# Altium schematic sheet MiniDAP.SchDoc
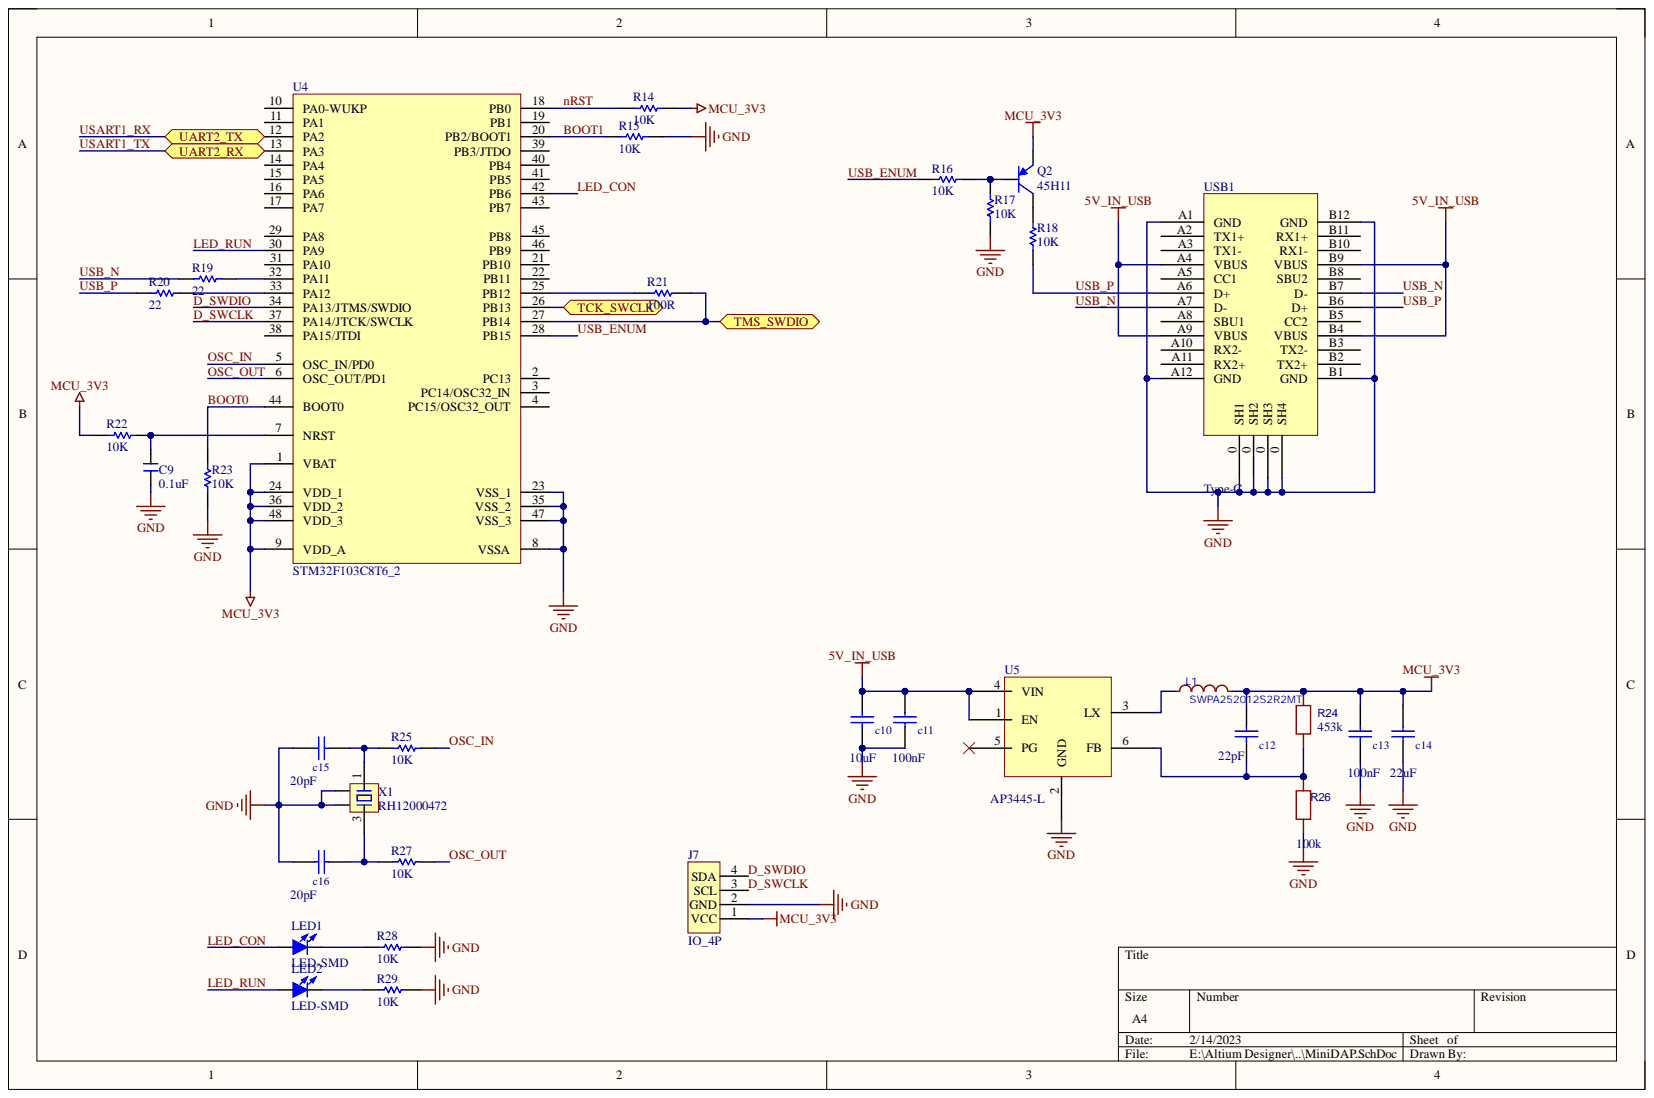
[diagram p0: MiniDAP.SchDoc]

## Parts
C9  0.1uF  [0603_C_Axon]
c10  10uF  [0603_C_Axon]
c11  100nF  [0603_C_Axon]
c12  22pF  [0402_C_Axon]
c13  100nF  [0603_C_Axon]
c14  22uF  [1206_C_Axon]
c15  20pF  [0603_C_Axon]
c16  20pF  [0603_C_Axon]
J7  IO_4P  [HDR1.27-LI-4P]
L1  SWPA252012S2R2MT  [SWPA252012S]
LED1  LED-SMD  [LED_0402_RED]
LED2  LED-SMD  [LED_0402_RED]
Q2  45H11  [SOT_23_3_L_A]
R14  10K  [0603_R_Axon]
R15  10K  [0603_R_Axon]
R16  10K  [0603_R_Axon]
R17  10K  [0603_R_Axon]
R18  10K  [0603_R_Axon]
R19  22  [0603_R_Axon]
R20  22  [0603_R_Axon]
R21  100R  [0603_R_Axon]
R22  10K  [0603_R_Axon]
R23  10K  [0603_R_Axon]
R24  value 453k  [0603_R_Axon]  (hidden comment: RC0603FR-07453KL)
R25  10K  [0603_R_Axon]
R26  value 100k  [0603_R_Axon]  (hidden comment: RC0603FR-07100KL)
R27  10K  [0603_R_Axon]
R28  10K  [0603_R_Axon]
R29  10K  [0603_R_Axon]
U4  STM32F103C8T6_2  [LQFP48_L]
U5  AP3445-L  [SOP6P95_300X285X130L45X42M]
USB1  Type-C  [USB_TYPE_C_FEMALE_12_16P]
X1  RH12000472  [XTAL-SMD-NX3225SA]

## Ports
TCK_SWCLK, TMS_SWDIO, UART2_RX, UART2_TX

## Nets
5V_IN_USB: c10.2 c11.2 U5.1(EN) U5.4(VIN) USB1.A4(VBUS) USB1.A9(VBUS) USB1.B4(VBUS) USB1.B9(VBUS)
GND: C9.1 c10.1 c11.1 c13.1 c14.1 c15.1 c16.1 J7.2(GND) R15.2 R17.1 R23.2 R26.1 R28.2 R29.2 U4.8(VSSA) U4.23(VSS_1) U4.35(VSS_2) U4.47(VSS_3) U5.2(GND) USB1.0(SH1) USB1.A1(GND) USB1.A12(GND) USB1.B1(GND) USB1.B12(GND) X1.2 X1.4
MCU_3V3: c12.2 c13.2 c14.2 J7.1(VCC) L1.2 Q2.3 R14.2 R22.1 R24.2 U4.1(VBAT) U4.9(VDD_A) U4.24(VDD_1) U4.36(VDD_2) U4.48(VDD_3)
BOOT0: R23.1 U4.44(BOOT0)
BOOT1: R15.1 U4.20(PB2/BOOT1)
D_SWCLK: J7.3(SCL) U4.37(PA14/JTCK/SWCLK)
D_SWDIO: J7.4(SDA) U4.34(PA13/JTMS/SWDIO)
LED_CON: LED1.1 U4.42(PB6)
LED_RUN: LED2.1 U4.30(PA9)
nRST: R14.1 U4.18(PB0)
OSC_IN: R25.2 U4.5(OSC_IN/PD0)
OSC_OUT: R27.2 U4.6(OSC_OUT/PD1)
TCK_SWCLK: U4.26(PB13) ; port TCK_SWCLK
TMS_SWDIO: R21.2 U4.27(PB14) ; port TMS_SWDIO
USART1_RX: U4.12(PA2) ; port UART2_TX
USART1_TX: U4.13(PA3) ; port UART2_RX
USB_ENUM: R16.1 U4.28(PB15)
USB_N: R19.1 USB1.A7(D-) USB1.B7(D-)
USB_P: R18.2 R20.1 USB1.A6(D+) USB1.B6(D+)
NetC9_2: C9.2 c12.1 c15.2 c16.2 R22.2 R24.1 R25.1 R26.2 R27.1 U4.7(NRST) U5.6(FB) X1.1 X1.3
NetL1_1: L1.1 U5.3(LX)
NetLED1_2: LED1.2 R28.1
NetLED2_2: LED2.2 R29.1
NetQ2_1: Q2.1 R16.2 R17.2
NetQ2_2: Q2.2 R18.1
NetR19_2: R19.2 U4.32(PA11)
NetR20_2: R20.2 U4.33(PA12)
NetR21_1: R21.1 U4.25(PB12)

Not connected: U4 2(PC13) 3(PC14/OSC32_IN) 4(PC15/OSC32_OUT) 10(PA0-WUKP) 11(PA1) 14(PA4) 15(PA5) 16(PA6) 17(PA7) 19(PB1) 21(PB10) 22(PB11) 29(PA8) 31(PA10) 38(PA15/JTDI) 39(PB3/JTDO) 40(PB4) 41(PB5) 43(PB7) 45(PB8) 46(PB9); U5 5(PG); USB1 A2(TX1+) A3(TX1-) A5(CC1) A8(SBU1) A10(RX2-) A11(RX2+) B2(TX2+) B3(TX2-) B5(CC2) B8(SBU2) B10(RX1-) B11(RX1+)

## Library data of the parts
C9 = CAP: 电容; Component Kind: Standard; Library Name: MySchLib.SchLib
c10-c16 = CAP: Component Kind: Standard; Library Name: InfineonRadar.SCHLIB
  c10: Description: 10uF; Value: 10uF
  c11 c13: Description: 100nF; Value: 100nF
  c12: Description: 22pF; Value: 22pF
  c14: Description: 22uF; Value: 22uF
  c15 c16: Description: 20pF; Value: 20pF
L1 = Inductor: 电感 2.2uH; Component Kind: Standard; Library Name: InfineonRadar.SCHLIB
LED1 LED2 = LED-SMD: LED; Component Kind: Standard; Library Name: MySchLib.SchLib
Q2 = 45H11: 互补功率-PNP型; 属性: 80V 8A 50W; 版权归属: 封装库来自淘宝 ID：黄晶宝石; BOM名称: 互补功率-PNP型; Component Kind: Standard; Library Name: MySchLib.SchLib; 技术支持，联系售后: https://shop463601444.taobao.com
R14-R23 R25 R27-R29 = RES: 电阻; Component Kind: Standard; LatestRevisionDate: 17-Jul-2002; LatestRevisionNote: Re-released for DXP Platform.; Library Name: MySchLib.SchLib; PackageReference: AXIAL-0.3; Published: 8-Jun-2000; Publisher: Altium Limited
R24 = RES: 453k; Component Kind: Standard; Library Name: InfineonRadar.SCHLIB
R26 = RES: 100k; Component Kind: Standard; Library Name: InfineonRadar.SCHLIB
U5 = AP3445-L: 1MHZ, 2A STEP-DOWN DC-DC BUCK CONVERTER; Component Kind: Standard; Library Name: InfineonRadar.SCHLIB
USB1 = USB_Type_C_TOP: Component Kind: Standard; Library Name: MySchLib.SchLib
X1 = XTAL-4P: 创捷 12M 4脚无源晶振; 版权归属: 封装库来自淘宝卖家 ID：黄晶宝石; BOM名称: 4脚无源晶振; Component Kind: Standard; 学习资源: https://shop463601444.taobao.com; Library Name: InfineonRadar.SCHLIB
J7 = IO_4P: Component Kind: Standard; Library Name: MySchLib.SchLib
U4 = STM32F103C8T6_2: Component Kind: Standard; Library Name: MySchLib.SchLib
